# Altium schematic sheet Peripherals.SchDoc
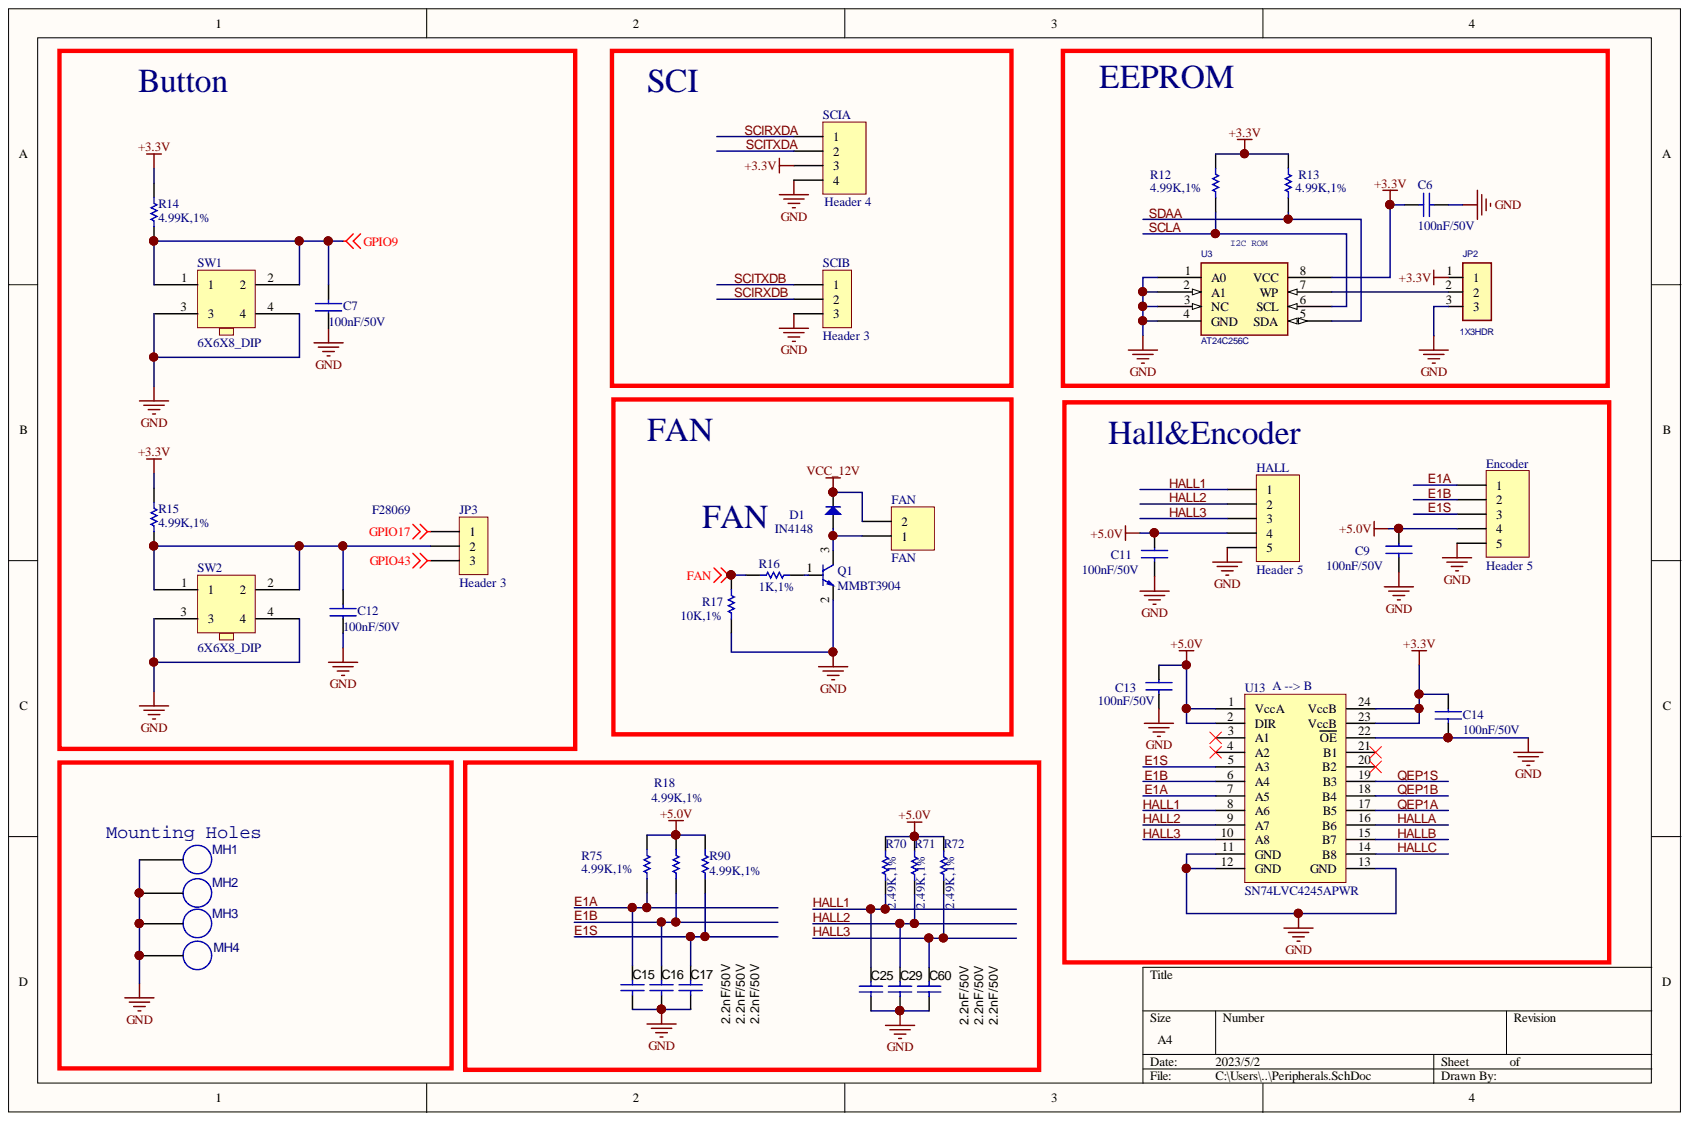
[diagram p0: Peripherals.SchDoc]

## Parts
C6  100nF/50V  [0603]
C7  100nF/50V  [0603]
C9  100nF/50V  [0603]
C11  100nF/50V  [0603]
C12  100nF/50V  [0603]
C13  100nF/50V  [0603]
C14  100nF/50V  [0603]
C15  2.2nF/50V  [0603]
C16  2.2nF/50V  [0603]
C17  2.2nF/50V  [0603]
C25  2.2nF/50V  [0603]
C29  2.2nF/50V  [0603]
C60  2.2nF/50V  [0603]
D1  IN4148  [SOD-80]
Encoder  Header 5  [15EDGK-3.81-05P]
FAN  FAN  [Molex_2.54_2P]
HALL  Header 5  [15EDGK-3.81-05P]
JP2  1X3HDR  [HDR1X3]
JP3  Header 3  [0603_3P]
MH1  MOUNT HOLE  [Hole]  (value not drawn)
MH2  MOUNT HOLE  [Hole]  (value not drawn)
MH3  MOUNT HOLE  [Hole]  (value not drawn)
MH4  MOUNT HOLE  [Hole]  (value not drawn)
Q1  MMBT3904  [SOT-233]
R12  4.99K,1%  [0603]
R13  4.99K,1%  [0603]
R14  4.99K,1%  [0603]
R15  4.99K,1%  [0603]
R16  1K,1%  [0603]
R17  10K,1%  [0603]
R18  4.99K,1%  [0603]
R70  2.49K,1%  [0603]
R71  2.49K,1%  [0603]
R72  2.49K,1%  [0603]
R75  4.99K,1%  [0603]
R90  4.99K,1%  [0603]
SCIA  Header 4  [HDR1X4]
SCIB  Header 3  [HDR1X3]
SW1  6X6X8_DIP  [TSW_6X6X5_DIP]
SW2  6X6X8_DIP  [TSW_6X6X5_DIP]
U3  AT24C256C  [SOIC8_3.90MM]
U13  SN74LVC4245APWR  [TSSOP24_4.4MM]

## Nets
+3.3V: C6.1 C14.1 JP2.1 R12.1 R13.1 R14.1 R15.1 SCIA.3 U3.8(VCC) U13.23(VccB) U13.24(VccB)
+5.0V: C9.1 C11.1 C13.1 Encoder.4 HALL.4 R18.1 R70.1 R71.1 R72.1 R75.1 R90.1 U13.1(VccA) U13.2(DIR)
FAN: R16.1 R17.1
GND: C6.2 C7.1 C9.2 C11.2 C12.1 C13.2 C14.2 C15.2 C16.2 C17.2 C25.2 C29.2 C60.2 Encoder.5 HALL.5 JP2.3 MH1.1 MH2.1 MH3.1 MH4.1 Q1.2 R17.2 SCIA.4 SCIB.3 SW1.3 SW1.4 SW2.3 SW2.4 U3.1(A0) U3.2(A1) U3.3(NC) U3.4(GND) U13.11(GND) U13.12(GND) U13.13(GND) U13.22(OE)
GPIO9: C7.2 R14.2 SW1.1 SW1.2
GPIO17: JP3.1
GPIO43: JP3.3
VCC_12V: D1.2 FAN.2
E1A: C15.1 Encoder.1 R75.2 U13.7(A5)
E1B: C16.1 Encoder.2 R18.2 U13.6(A4)
E1S: C17.1 Encoder.3 R90.2 U13.5(A3)
HALL1: C25.1 HALL.1 R70.2 U13.8(A6)
HALL2: C29.1 HALL.2 R71.2 U13.9(A7)
HALL3: C60.1 HALL.3 R72.2 U13.10(A8)
HALLA: U13.16(B6)
HALLB: U13.15(B7)
HALLC: U13.14(B8)
QEP1A: U13.17(B5)
QEP1B: U13.18(B4)
QEP1S: U13.19(B3)
SCIRXDA: SCIA.1
SCIRXDB: SCIB.2
SCITXDA: SCIA.2
SCITXDB: SCIB.1
SCLA: R12.2 U3.6(SCL)
SDAA: R13.2 U3.5(SDA)
NetC12_2: C12.2 JP3.2 R15.2 SW2.1 SW2.2
NetD1_1: D1.1 FAN.1 Q1.3
NetJP2_2: JP2.2 U3.7(WP)
NetQ1_1: Q1.1 R16.2

Not connected: U13 3(A1) 4(A2) 20(B2) 21(B1)

## Library data of the parts
C6 = Cap Semi: Value: 10nF; Component Kind: Standard; LatestRevisionDate: 17-Jul-2002; LatestRevisionNote: Re-released for DXP Platform.; Library Name: F28335.SCHLIB; PackageReference: C1206; Published: 8-Jun-2000; Publisher: Altium Limited
C7 C9 C11-C14 = Component-CAP: User Parameter 1: foot; Component Kind: Standard; Library Name: AltiumDesigner_Lib-tony.SchLib
C15-C17 C25 C29 C60 = GRM188R71C222KA01D: Manufacturer: MuRata; Cap_F: 0.0000000022; CompType: Capacitor; Polarized: N; TaMax_C: 125; TaMin_C: -55; TempCo: X7R; Tolerance_%: 10; U/M: EA; Value: 2200pF; Value1: Unknown; Value2: 16V; VDC_V: 16; Cap_Case: 0603; Component Kind: Standard; ComponentLink1Description: Datasheet link; ComponentLink1URL: http://search.murata.co.jp/Ceramy/PnsearchViewAction.do?HnOFG=ON&HnNFG=ON&SEL_CNT=50&sLang=en&sKey=GRM188R71C222KA01D&x=9&y=9&search=startwith; LatestRevisionDate: 2014-02-11; Library Name: Capacitor_Ceramic.IntLib; MountType: SMT; PackageReference: 0603; PartNumber: GRM188R71C222KA01D; Published: 2008-06-19; RoHS: Y; Signal Integrity: Cap; Simulation: Cap; Supplier 1: Digi-Key; Supplier 2: Mouser; Supplier Part Number 1: GRM188R71C222KA01D-ND; Supplier Part Number 2: 81-GRM188R71C222KA1D; SymbolVersion: 2008-07-09; Technology: Ceramic; ValueDisplayed: 2200pF; Publisher: Texas Instruments
D1 = Diode-Std: Component Kind: Standard; Library Name: AltiumDesigner_Lib-syc.SchLib
FAN = Header 2: Component Kind: Standard; LatestRevisionDate: 17-Jul-2002; LatestRevisionNote: Re-released for DXP Platform.; Library Name: Miscellaneous Connectors.IntLib; Signal Integrity: Connector; Publisher: Altium Limited
JP2 = 1X3HDR: Part Number: 320-00019; Vendor: Harwin; Vendor PN: M22-2510305; Component Kind: Standard; Distributor: digikey; Distributor Part Number: 952-1312-ND; Part Type: CONN
MH1-MH4 = MOUNT_HOLE_1: Part Number: D3-0100035; Component Height: 0.01MM; Component Kind: Standard
Q1 = Tran-NPN_1: Code - JEDEC: TO-92A; Revision 1: 11-Jul-2000: SIM Model Added.; Revision 2: 17-Jul-2002: Re-released for DXP Platform.; Revision 3: 15-Jan-2003: PCB Footprint 'BCY-W3/D4.7' replaced; Component Kind: Standard; Datasheet: Latest Revision: 1997; Package Reference: TO-92A; Published: 8-Jun-2000; Library Name: AltiumDesigner_Lib-syc.SchLib; Publisher: Altium Limited
R12 R13 R15 R18 R70-R72 R75 R90 = Res1: Value: 2.2K; Component Kind: Standard; LatestRevisionDate: 17-Jul-2002; LatestRevisionNote: Re-released for DXP Platform.; Library Name: Miscellaneous Devices.IntLib; PackageReference: AXIAL-0.3; Published: 8-Jun-2000; Signal Integrity: Res; Simulation: RESISTOR; Publisher: Altium Limited
R14 = Res1: Resistor; Value: 2.2K; Component Kind: Standard; LatestRevisionDate: 17-Jul-2002; LatestRevisionNote: Re-released for DXP Platform.; Library Name: Miscellaneous Devices.IntLib; PackageReference: AXIAL-0.3; Published: 8-Jun-2000; Signal Integrity: Res; Simulation: RESISTOR; Publisher: Altium Limited
R16 = Res Semi: Value: 20K; Component Kind: Standard; LatestRevisionDate: 17-Jul-2002; LatestRevisionNote: Re-released for DXP Platform.; Library Name: XDS100V3.SCHLIB; PackageReference: AXIAL-0.5; Published: 8-Jun-2000; Signal Integrity: Res Semi; Simulation: RES; Publisher: Altium Limited
R17 = Res Semi: Value: 12K; Component Kind: Standard; LatestRevisionDate: 17-Jul-2002; LatestRevisionNote: Re-released for DXP Platform.; Library Name: Miscellaneous Devices.IntLib; PackageReference: AXIAL-0.5; Published: 8-Jun-2000; Signal Integrity: Res Semi; Simulation: RES; Publisher: Altium Limited
SCIA = Header 4: Header, 4-Pin; Component Kind: Standard; LatestRevisionDate: 17-Jul-2002; LatestRevisionNote: Re-released for DXP Platform.; Library Name: Miscellaneous Connectors.IntLib; Signal Integrity: Connector; Publisher: Altium Limited
SW1 SW2 = ATX-F-4P: Component Kind: Standard; Library Name: AltiumDesigner_Lib-syc.SchLib
U3 = I2C_ROM_2ADDR: IC EEPROM 256KBIT IND 8SOIC; Part Number: 402-00040; Vendor: Atmel; Vendor PN: AT24C256C-SSHL-B; Component Kind: Standard; Distributor: digikey; Distributor Part Number: AT24C256C-SSHL-B-ND; Part Type: IC; Library Name: CONCERTO_DIMM100_071211_Library.SchLib
U13 = TI-SN74LVC4245A: Component Kind: Standard; Library Name: AltiumDesigner_Lib-syc.SchLib
Encoder HALL = Header 5: Component Kind: Standard; LatestRevisionDate: 17-Jul-2002; LatestRevisionNote: Re-released for DXP Platform.; Library Name: Miscellaneous Connectors.IntLib; Signal Integrity: Connector; Publisher: Altium Limited
JP3 SCIB = Header 3: Component Kind: Standard; Revision: 17-Jul-2002: Re-released for DXP Platform.; Library Name: AltiumDesigner_Lib-tony.IntLib
